# Altium schematic sheet Secure Gateway Teensy 4 Prototype - Rev 2.SchDoc("Secure Gateway Teensy 4 Prototype - Rev 2")
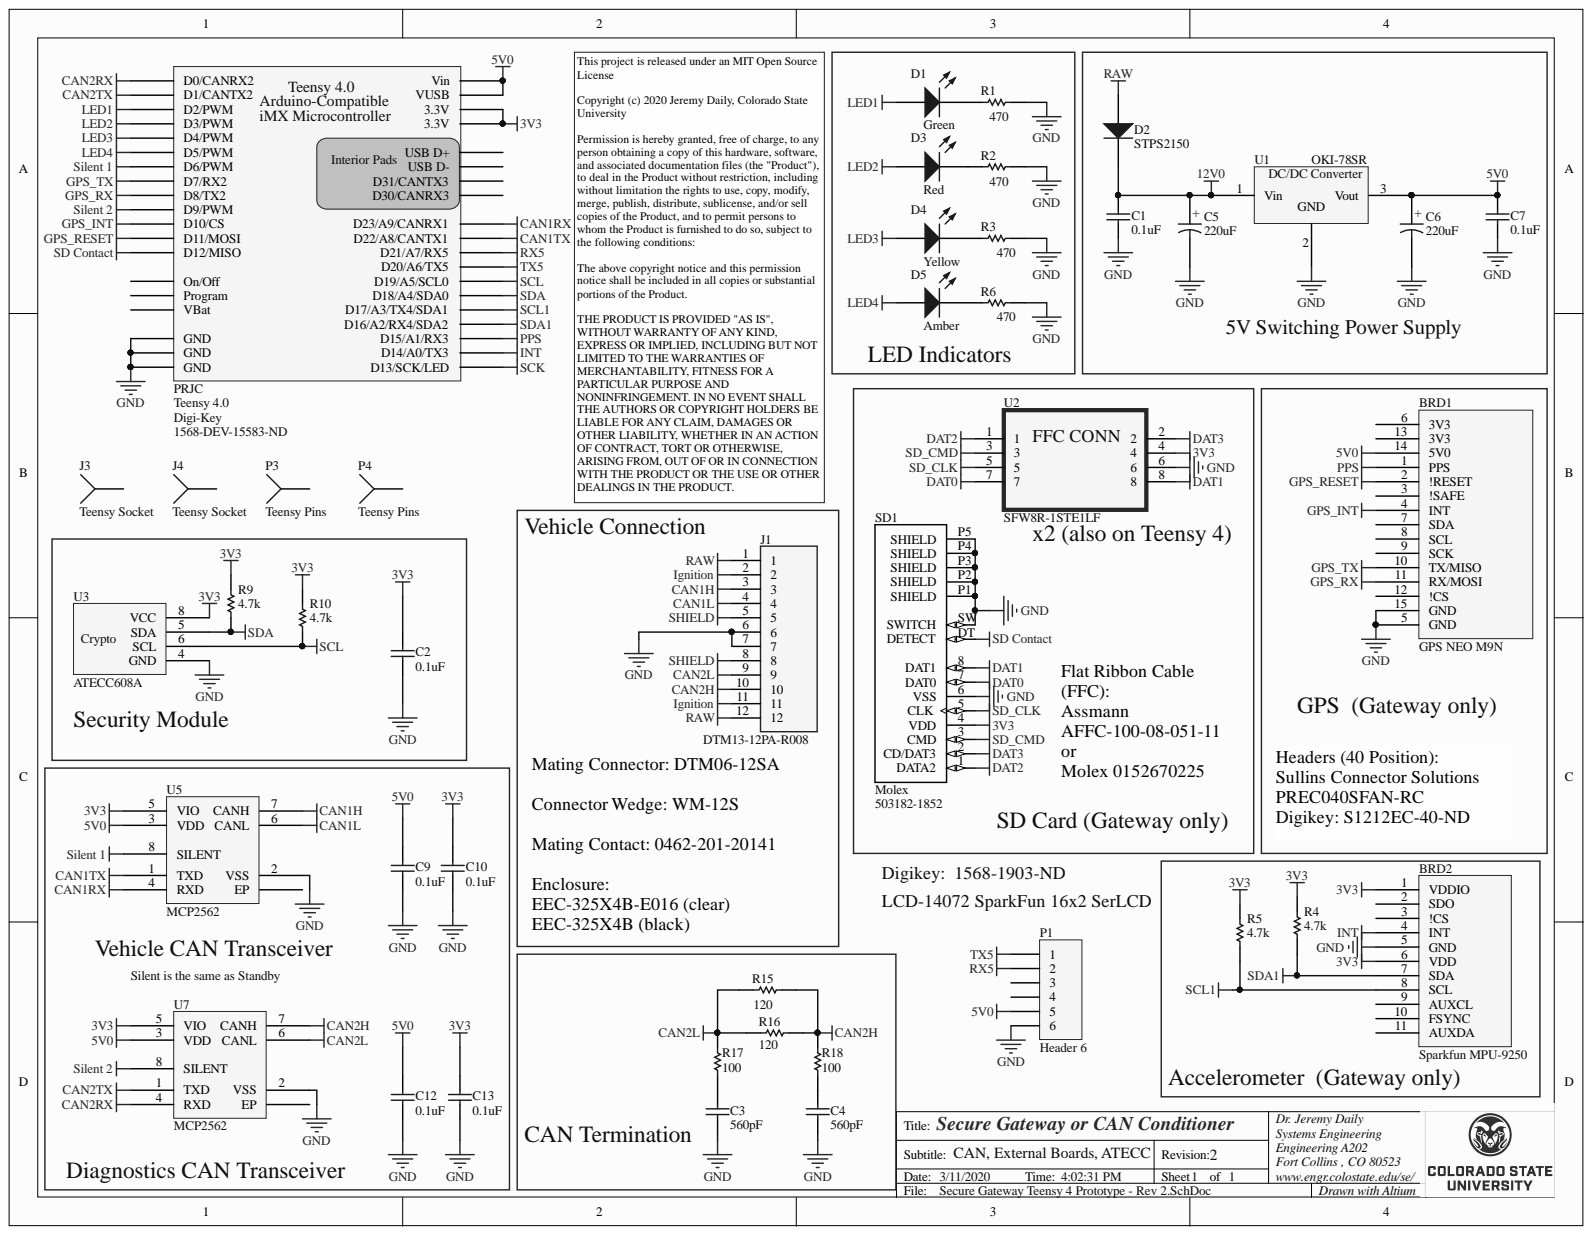
[diagram p0: Secure Gateway Teensy 4 Prototype - Rev 2.SchDoc]

## Parts
BRD1  GPS NEO M9N  [GPS NEO M9N]
BRD2  Sparkfun MPU-9250  [MPU9250 Breakout]
C1  0.1uF  [CAPR5-4X5]
C2  0.1uF  [CAPR5-4X5]
C3  560pF  [CAPR5-4X5]
C4  560pF  [CAPR5-4X5]
C5  220uF  [Elec. Cap 5 x 10mm]
C6  220uF  [Elec. Cap 5 x 10mm]
C7  0.1uF  [CAPR5-4X5]
C9  0.1uF  [CAPR5-4X5]
C10  0.1uF  [CAPR5-4X5]
C12  0.1uF  [CAPR5-4X5]
C13  0.1uF  [CAPR5-4X5]
D1  Green  [5mm Rad LED]
D2  STPS2150  [DO-204AL]
D3  Red  [5mm Rad LED]
D4  Yellow  [5mm Rad LED]
D5  Amber  [5mm Rad LED]
J1  DTM13-12PA-R008  [TE_DTM13-12PA-R008]
J3  Teensy Socket
J4  Teensy Socket
P1  Header 6  [HDR1X6]
P3  Teensy Pins
P4  Teensy Pins
R1  470  [AXIAL-0.4]
R2  470  [AXIAL-0.4]
R3  470  [AXIAL-0.4]
R4  4.7k  [AXIAL-0.4]
R5  4.7k  [AXIAL-0.4]
R6  470  [AXIAL-0.4]
R9  4.7k  [AXIAL-0.4]
R10  4.7k  [AXIAL-0.4]
R15  120  [AXIAL-0.4]
R16  120  [AXIAL-0.4]
R17  100  [AXIAL-0.4]
R18  100  [AXIAL-0.4]
SD1  503182-1852  Manufacturer: Molex  [MOLEX_503182-1852]
Teensy_1  Teensy 4.0  Manufacturer: PRJC  [Teensy 4.0]
U1  OKI-78SR  [OKI-78SR]
U2  SFW8R-1STE1LF  [FCI_SFW8R-1STE1LF]
U3  ATECC608A  [SOIC-8]
U5  MCP2562  [DIP-8]
U7  MCP2562  [DIP-8]

## Nets
3V3: BRD2.1(VDDIO) BRD2.6(VDD) C2.2 C10.2 C13.2 R4.1 R5.1 R9.1 R10.1 SD1.4(VDD) Teensy_1.3V3a(3.3V) Teensy_1.3V3b(3.3V) U2.4 U3.8(VCC) U5.5(VIO) U7.5(VIO)
5V0: BRD1.14(5V0) C6.1 C7.2 C9.2 C12.2 P1.5 Teensy_1.Vin Teensy_1.VUSB U1.3 U5.3(VDD) U7.3(VDD)
12V0: C1.2 C5.1 D2.2 U1.1
CAN1H: J1.3 U5.7(CANH)
CAN1L: J1.4 U5.6(CANL)
CAN1RX: Teensy_1.23(D23/A9/CANRX1) U5.4(RXD)
CAN1TX: Teensy_1.22(D22/A8/CANTX1) U5.1(TXD)
CAN2H: J1.10 R15.2 R16.2 R18.2 U7.7(CANH)
CAN2L: J1.9 R15.1 R16.1 R17.2 U7.6(CANL)
CAN2RX: Teensy_1.0(D0/CANRX2) U7.4(RXD)
CAN2TX: Teensy_1.1(D1/CANTX2) U7.1(TXD)
DAT0: SD1.7(DAT0) U2.7
DAT1: SD1.8(DAT1) U2.8
DAT2: SD1.1(DATA2) U2.1
DAT3: SD1.2(CD/DAT3) U2.2
GND: BRD1.5(GND) BRD1.15(GND) BRD2.5(GND) C1.1 C2.1 C3.2 C4.2 C5.2 C6.2 C7.1 C9.1 C10.1 C12.1 C13.1 J1.6 J1.7 P1.6 R1.2 R2.2 R3.2 R6.2 SD1.6(VSS) SD1.P1(SHIELD) SD1.P2(SHIELD) SD1.P3(SHIELD) SD1.P4(SHIELD) SD1.P5(SHIELD) SD1.SW(SWITCH) Teensy_1.G1(GND) Teensy_1.G2(GND) Teensy_1.G3(GND) U1.2 U2.6 U3.4(GND) U5.2(VSS) U7.2(VSS)
GPS_INT: BRD1.4(INT) Teensy_1.10(D10/CS)
GPS_RESET: BRD1.2(!RESET) Teensy_1.11(D11/MOSI)
GPS_RX: BRD1.11(RX/MOSI) Teensy_1.8(D8/TX2)
GPS_TX: BRD1.10(TX/MISO) Teensy_1.7(D7/RX2)
Ignition: J1.2 J1.11
INT: BRD2.4(INT) Teensy_1.14(D14/A0/TX3)
LED1: D1.1 Teensy_1.2(D2/PWM)
LED2: D3.1 Teensy_1.3(D3/PWM)
LED3: D4.1 Teensy_1.4(D4/PWM)
LED4: D5.1 Teensy_1.5(D5/PWM)
PPS: BRD1.1(PPS) Teensy_1.15(D15/A1/RX3)
RAW: D2.1 J1.1 J1.12
RX5: P1.2 Teensy_1.21(D21/A7/RX5)
SCK: Teensy_1.13(D13/SCK/LED)
SCL: R10.2 Teensy_1.19(D19/A5/SCL0) U3.6(SCL)
SCL1: BRD2.8(SCL) R5.2 Teensy_1.17(D17/A3/TX4/SDA1)
SD Contact: SD1.DT(DETECT) Teensy_1.12(D12/MISO)
SD_CLK: SD1.5(CLK) U2.5
SD_CMD: SD1.3(CMD) U2.3
SDA: R9.2 Teensy_1.18(D18/A4/SDA0) U3.5(SDA)
SDA1: BRD2.7(SDA) R4.2 Teensy_1.16(D16/A2/RX4/SDA2)
SHIELD: J1.5 J1.8
Silent 1: Teensy_1.6(D6/PWM) U5.8(SILENT)
Silent 2: Teensy_1.9(D9/PWM) U7.8(SILENT)
TX5: P1.1 Teensy_1.20(D20/A6/TX5)
NetC3_1: C3.1 R17.1
NetC4_1: C4.1 R18.1
NetD1_2: D1.2 R1.1
NetD3_2: D3.2 R2.1
NetD4_2: D4.2 R3.1
NetD5_2: D5.2 R6.1

Not connected: BRD1 3(!SAFE) 6(3V3) 7(SDA) 8(SCL) 9(SCK) 12(!CS) 13(3V3); BRD2 2(SDO) 3(!CS) 9(AUXCL) 10(FSYNC) 11(AUXDA); J3 1; J4 1; P1 3 4; P3 1; P4 1; Teensy_1 CRX3(D30/CANRX3) CTX3(D31/CANTX3) D+(USB_D+) D- On(On/Off) P(Program) VBat; U5 0(EP); U7 0(EP)

## Library data of the parts
BRD1 = GPS NEO M9N: PartNumber: GPS-15733; Manufacturer: SparkFun Electronics; Supplier Part Number 1: 1568-GPS-15733-ND; Supplier 1: Digi-Key; Library Name: Custom Parts Schematics.SchLib; Component Kind: Standard
BRD2 = MPU-9350 Breakout: PartNumber: SEN-13762; Manufacturer: SparkFun Electronics; Library Name: Custom Parts.IntLib; Component Kind: Standard
C1 C2 C7 C9 C10 C12 C13 = Cap: 0.1µF ±10% 50V Ceramic Capacitor X7R Radial; PartNumber: FA28X7R1H104KNU00; Manufacturer: TDK Corporation; Alternative: Acceptable; Supplier Part Number 1: 445-175529-ND; Supplier 1: Digi-Key; Simulation: CAP; Signal Integrity: Cap; Component Kind: Standard
  C1 C7: Library Name: SSS Schematic Library.SchLib
  C2 C9 C10 C12 C13: Library Name: SSS Integrated Library.IntLib
C3 C4 = Cap: 560pF ±5% 100V Ceramic Capacitor C0G, NP0 Radial; PartNumber: FA28C0G2A561JNU00; Manufacturer: TDK Corporation; Alternative: Acceptable; Supplier Part Number 1: 445-175528-ND; Supplier 1: Digi-Key; Simulation: CAP; Signal Integrity: Cap; Library Name: SSS Integrated Library.IntLib; Component Kind: Standard
C5 C6 = Electrolytic Cap: 220µF 50V Aluminum Electrolytic Capacitors Radial, Can 175 mOhm @ 10kHz 5000 Hrs @ 105°C; PartNumber: B41858C6227M000; Manufacturer: EPCOS (TDK); Supplier Part Number 1: 495-6000-ND; Supplier 1: Digi-Key; Library Name: SSS Integrated Library.IntLib; Component Kind: Standard
D1 = LED - SMD: Green 569nm LED Indication - Discrete 2.1V Radial; Manufacturer: Lite-On Inc.; Supplier Part Number 1: 160-1130-ND; Supplier 1: Digi-Key; PartNumber: LTL-4233; Library Name: SSS Integrated Library.IntLib; Component Kind: Standard
D2 = Diode 1N4003: Diode Schottky 150V 2A Through Hole DO-15; Manufacturer: STMicroelectronics; Supplier Part Number 1: 497-3213-1-ND; Supplier 1: Digi-Key; Simulation: 1N4003; Signal Integrity: 1N4003; PartNumber: STPS2150; Library Name: Miscellaneous Devices.IntLib; Component Kind: Standard
D3 = LED - SMD: Red 623nm LED Indication - Discrete 2V Radial; Manufacturer: Lite-On Inc.; Supplier Part Number 1: 160-1127-ND; Supplier 1: Digi-Key; PartNumber: LTL-4223; Library Name: SSS Integrated Library.IntLib; Component Kind: Standard
D4 = LED - SMD: Yellow 588nm LED Indication - Discrete 2.1V Radial; Manufacturer: Lite-On Inc.; Supplier Part Number 1: 160-1133-ND; Supplier 1: Digi-Key; PartNumber: LTL-4253; Library Name: SSS Integrated Library.IntLib; Component Kind: Standard
D5 = LED - SMD: Amber 588nm LED Indication - Discrete 2.1V Radial; Manufacturer: Lite-On Inc.; Supplier Part Number 1: 160-1966-ND; Supplier 1: Digi-Key; PartNumber: LTL-4273; Library Name: SSS Integrated Library.IntLib; Component Kind: Standard
J1 = DTM13-12PA-R008: DTM Series, 4.19mm Pitch 12 Way 2 Row Right Angle PCB Header, Solder Termination; PartNumber: TE Connectivity Deutsch Connectors; Supplier Part Number 1: 1734-1130-ND; Supplier 1: Digi-Key; Manufacturer: TE Connectivity Deutsch Connectors; Library Name: DTM13-12PA-R008.IntLib; Component Kind: Standard
J3 J4 = Socket: 14 Position Header Connector  Through Hole; PartNumber: PPTC141LFBN-RC; Manufacturer: Sullins Connector Solutions; Supplier Part Number 1: S7012-ND; Supplier 1: Digi-Key; Signal Integrity: Connector; Library Name: Miscellaneous Connectors.IntLib; Component Kind: Standard
P1 = Header 6: Header, 6-Pin; PartNumber: PREC040SFAN-RC‎; Manufacturer: Sullins Connector Solutions; Supplier Part Number 1: S1212EC-40-ND‎; Supplier 1: Digi-Key; Signal Integrity: Connector; Library Name: Miscellaneous Connectors.IntLib; Component Kind: Standard
P3 P4 = Socket: Connector Header Through Hole 14 position 0.100" (2.54mm); PartNumber: PREC014SAAN-RC; Manufacturer: Sullins Connector Solutions; Supplier Part Number 1: S1012EC-14-ND; Supplier 1: Digi-Key; Signal Integrity: Connector; Library Name: Miscellaneous Connectors.IntLib; Component Kind: Standard
R1-R3 R6 = Chip Resistor: 330 Ohms ±1% 0.1W, 1/10W Chip Resistor 0603 (1608 Metric) Automotive AEC-Q200, High Voltage Thick Film; PartNumber: CF14JT470R; Manufacturer: Stackpole Electronics Inc; Alternative: Acceptable; Supplier Part Number 1: CF14JT470RCT-ND; Supplier 1: Digi-Key; Library Name: SSS Integrated Library.IntLib; Component Kind: Standard
R4 R5 R9 R10 = Chip Resistor: 4.7 kOhms ±5% 0.25W, 1/4W Through Hole Resistor Axial Flame Retardant Coating, Safety Carbon Film; PartNumber: CF14JT4K70; Manufacturer: Stackpole Electronics Inc; Alternative: Acceptable; Supplier Part Number 1: CF14JT4K70CT-ND; Supplier 1: Digi-Key; Library Name: SSS Integrated Library.IntLib; Component Kind: Standard
R15 R16 = Chip Resistor: 120 Ohms ±5% 0.25W, 1/4W Through Hole Resistor Axial Flame Retardant Coating, Safety Carbon Film; PartNumber: CF14JT120RCT-ND; Manufacturer: Stackpole Electronics Inc; Alternative: Acceptable; Supplier Part Number 1: CF14JT120RCT-ND; Supplier 1: Digi-Key; Library Name: SSS Integrated Library.IntLib; Component Kind: Standard
R17 R18 = Chip Resistor: 100 Ohms ±5% 0.25W, 1/4W Through Hole Resistor Axial Flame Retardant Coating, Safety Carbon Film; PartNumber: CF14JT100R; Manufacturer: Stackpole Electronics Inc; Alternative: Acceptable; Supplier Part Number 1: CF14JT100RCT-ND; Supplier 1: Digi-Key; Library Name: SSS Integrated Library.IntLib; Component Kind: Standard
SD1 = 503182-1852: MICRO SD NORMAL ULTRALOWPRO8CKTE; PartNumber: 5031821852; Supplier Part Number 1: WM12834CT-ND; Supplier 1: Digi-Key; Library Name: 503182-1852.SchLib; Component Kind: Standard
Teensy_1 = Teensy_4.0: 32 Bit Arduino-Compatible iMX Microcontroller; Supplier Part Number 1: 1568-DEV-15583-ND; Supplier 1: Digi-Key; PartNumber: Teensy 4.0; Library Name: Teensy.IntLib; Component Kind: Standard
U1 = 7805: 5V DC/DC Converter (7805 replacement); PartNumber: OKI-78SR-5/1.5-W36H-C; Manufacturer: Murata Power Solutions Inc.; Supplier Part Number 1: 811-2692-ND; Supplier 1: Digi-Key; Library Name: SSS Integrated Library.IntLib; Component Kind: Standard
U2 = SFW8R-1STE1LF: 8 Position FFC, FPC Connector Contacts, Bottom 0.039 _1.00mm_ Surface Mount, Right Angle; Manufacturer: Amphenol ICC; Supplier Part Number 1: 609-1893-1-ND; PartNumber: SFW8R-1STE1LF; Library Name: SFW8R-1STE1LF.IntLib; Component Kind: Standard
U3 = ATECC508A: Authentication Chip IC Networking and Communications 8-SOIC; PartNumber: ATECC608A-SSHDA-T; Manufacturer: Microchip Technology; Supplier Part Number 1: ATECC608A-SSHDA-TCT-ND; Supplier 1: Digi-Key; Library Name: TU Custom Parts Schematics.SchLib; Component Kind: Standard
U5 U7 = MCP2558FD: Microchip CAN FD Transceiver with Silent Mode; PartNumber: MCP2562-E/P; Supplier Part Number 1: MCP2562-E/P-ND; Supplier 1: Digi-Key; Manufacturer: Microchip; Library Name: TU Custom Parts Schematics.SchLib; Component Kind: Standard
